# Altium schematic sheet Board.SchDoc
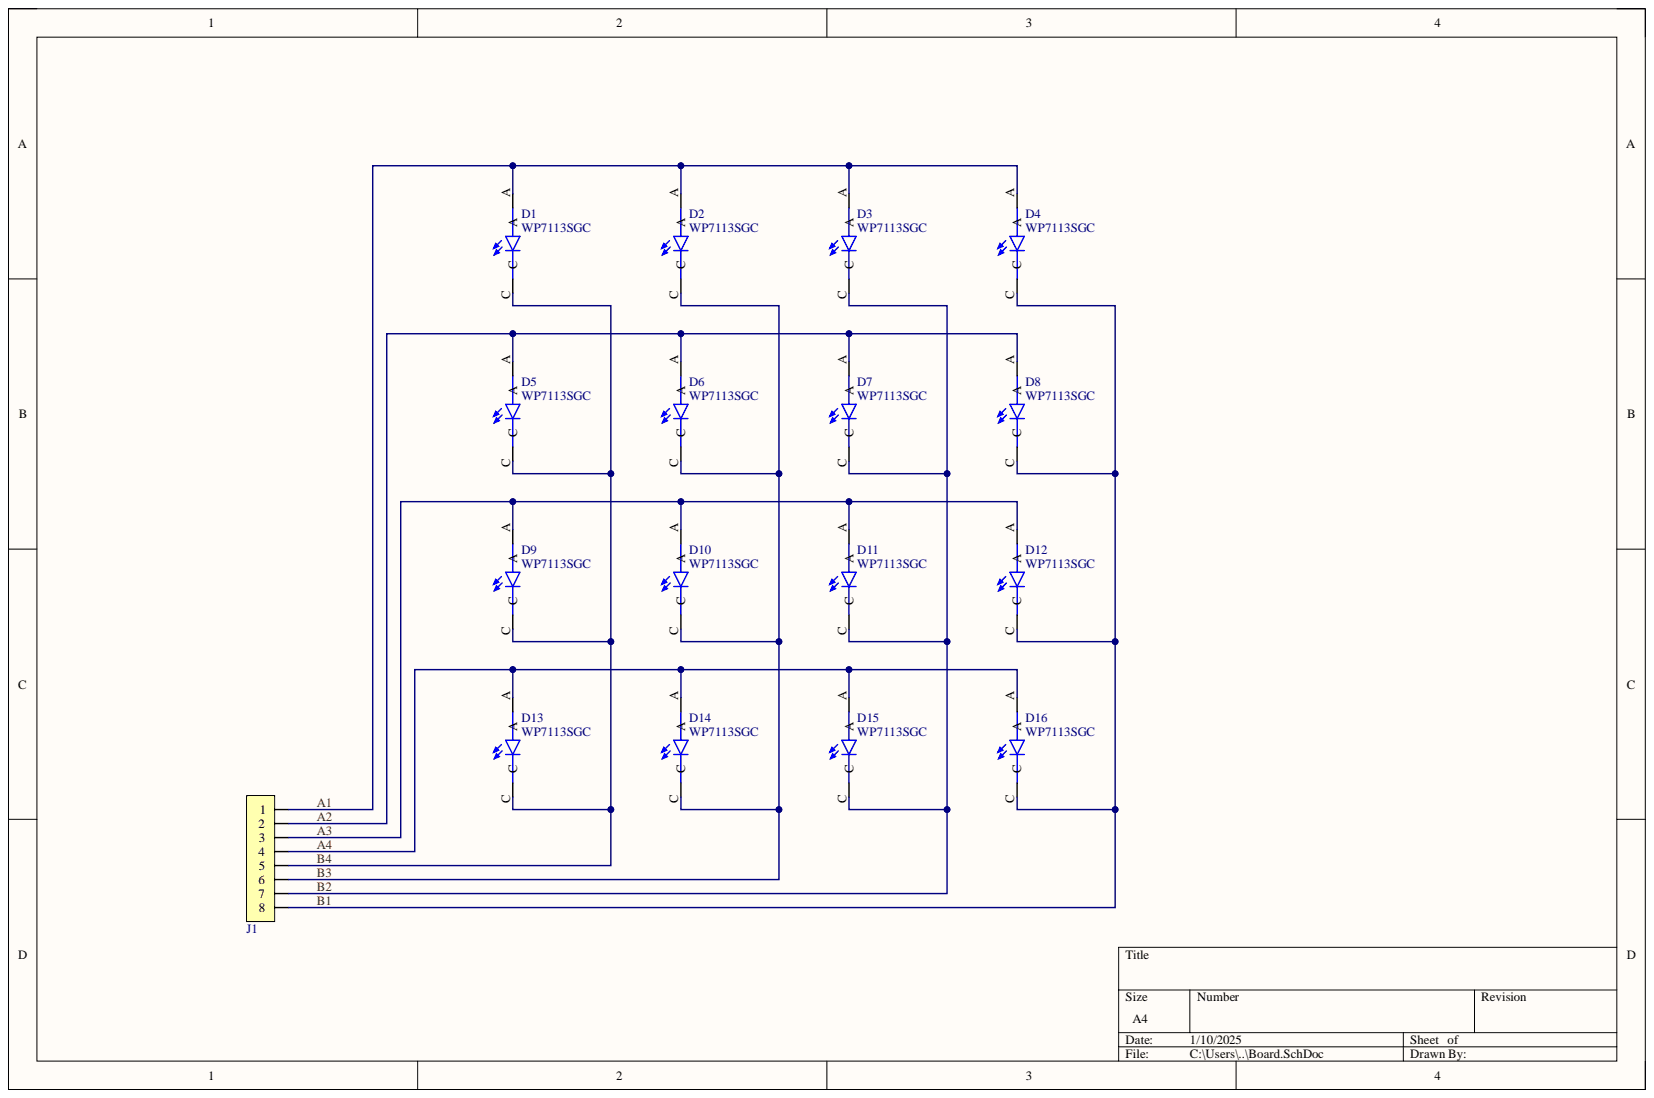
[diagram p0: Board.SchDoc]

## Parts
D1  WP7113SGC  [LED_WP7113SGC]
D2  WP7113SGC  [LED_WP7113SGC]
D3  WP7113SGC  [LED_WP7113SGC]
D4  WP7113SGC  [LED_WP7113SGC]
D5  WP7113SGC  [LED_WP7113SGC]
D6  WP7113SGC  [LED_WP7113SGC]
D7  WP7113SGC  [LED_WP7113SGC]
D8  WP7113SGC  [LED_WP7113SGC]
D9  WP7113SGC  [LED_WP7113SGC]
D10  WP7113SGC  [LED_WP7113SGC]
D11  WP7113SGC  [LED_WP7113SGC]
D12  WP7113SGC  [LED_WP7113SGC]
D13  WP7113SGC  [LED_WP7113SGC]
D14  WP7113SGC  [LED_WP7113SGC]
D15  WP7113SGC  [LED_WP7113SGC]
D16  WP7113SGC  [LED_WP7113SGC]
J1  8Pin_Male  [Header1x8_254]  (value not drawn)

## Nets
A1: D1.A D2.A D3.A D4.A J1.1
A2: D5.A D6.A D7.A D8.A J1.2
A3: D9.A D10.A D11.A D12.A J1.3
A4: D13.A D14.A D15.A D16.A J1.4
B1: D4.C D8.C D12.C D16.C J1.8
B2: D3.C D7.C D11.C D15.C J1.7
B3: D2.C D6.C D10.C D14.C J1.6
B4: D1.C D5.C D9.C D13.C J1.5

## Library data of the parts
D1-D16 = WP7113SGC: Green 568nm LED Indication - Discrete 2.2V Radial; MF: Kingbright; Availability: Unavailable; Component Kind: Standard; MP: WP7113SGC; Package: Radial Kingbright; Price: None; SnapEDA_Link: https://www.snapeda.com/parts/WP7113SGC/Kingbright/view-part/523411/?ref=snap; Library Name: FritzCustomComponets.IntLib
J1 = ArduinoConnector_8: Database Table Name: Connectors; Component Kind: Standard; ComponentLink1Description: Datasheet; ComponentLink1URL: https://katalog.we-online.de/em/datasheet/6130xx11121.pdf; Part ID: ArduinoConnector_8; Price 1pc (CHF): 0.36; Supplier 1: Digi-Key; Supplier Part Number 1: 732-5321-ND; System: Connector; Library Name: FHGR_Altium_database.DbLib
